# Altium schematic sheet T_Display_S3.SchDoc
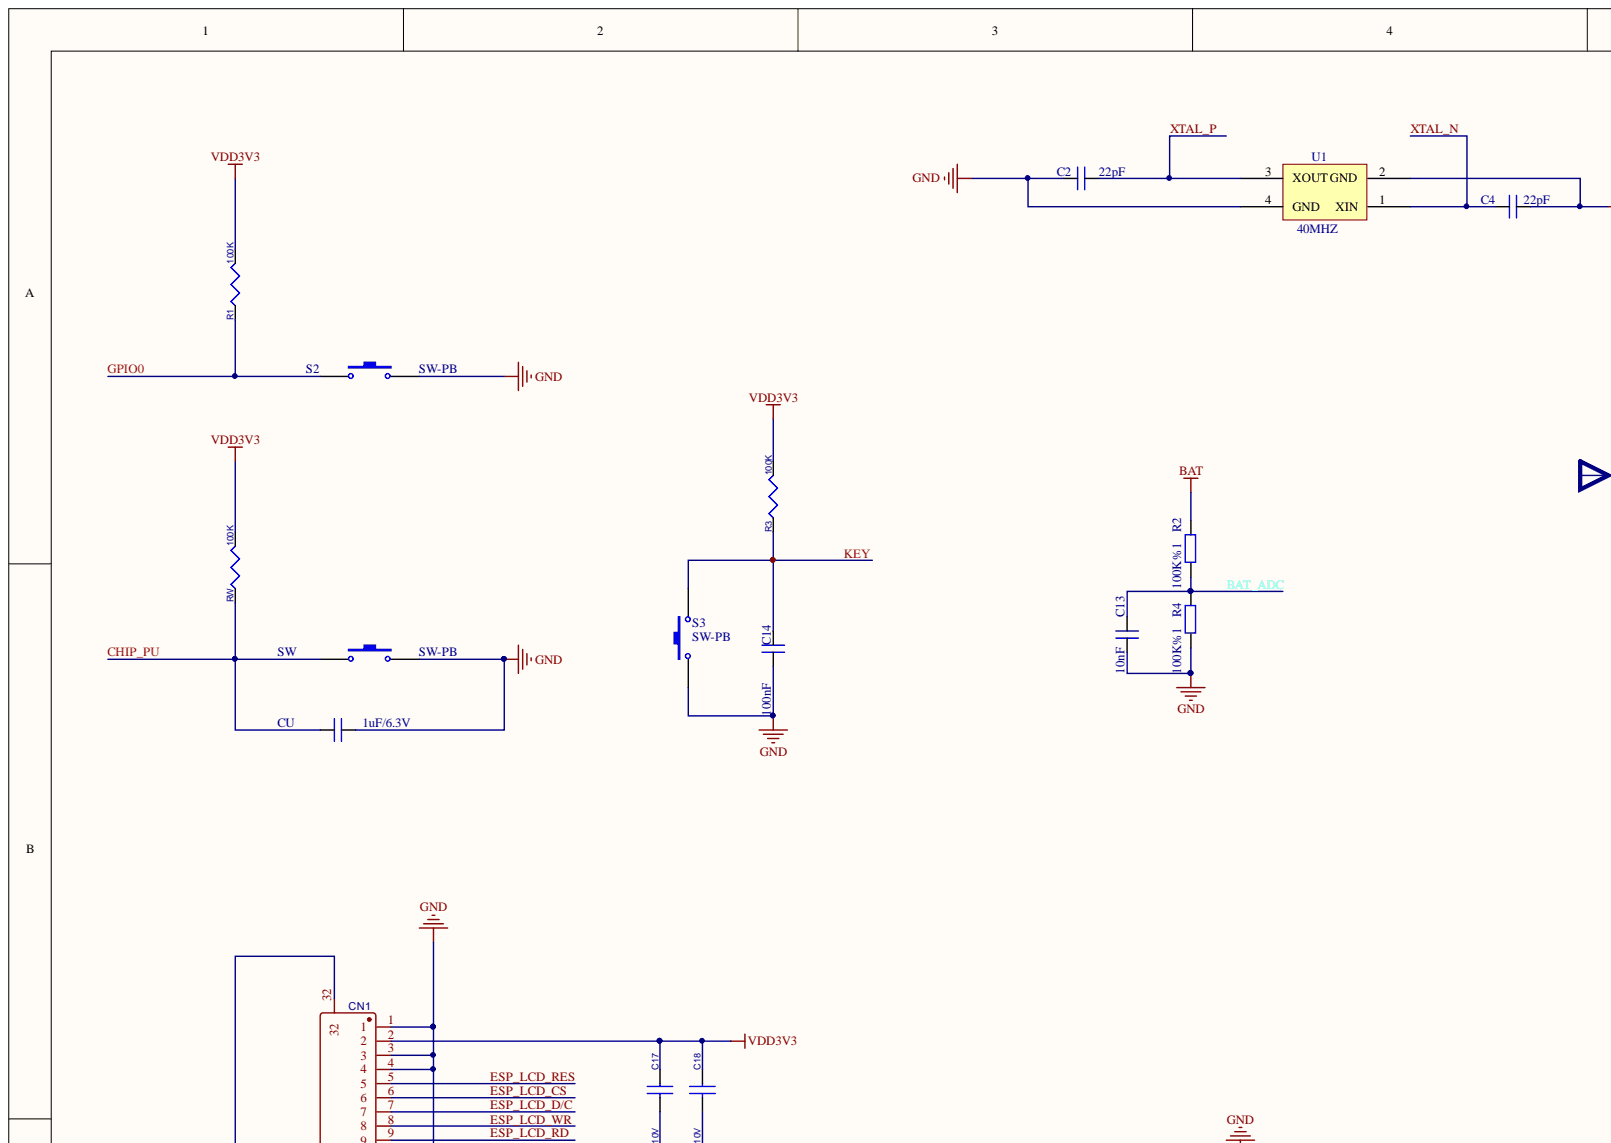
[diagram p0: T_Display_S3.SchDoc, top left]
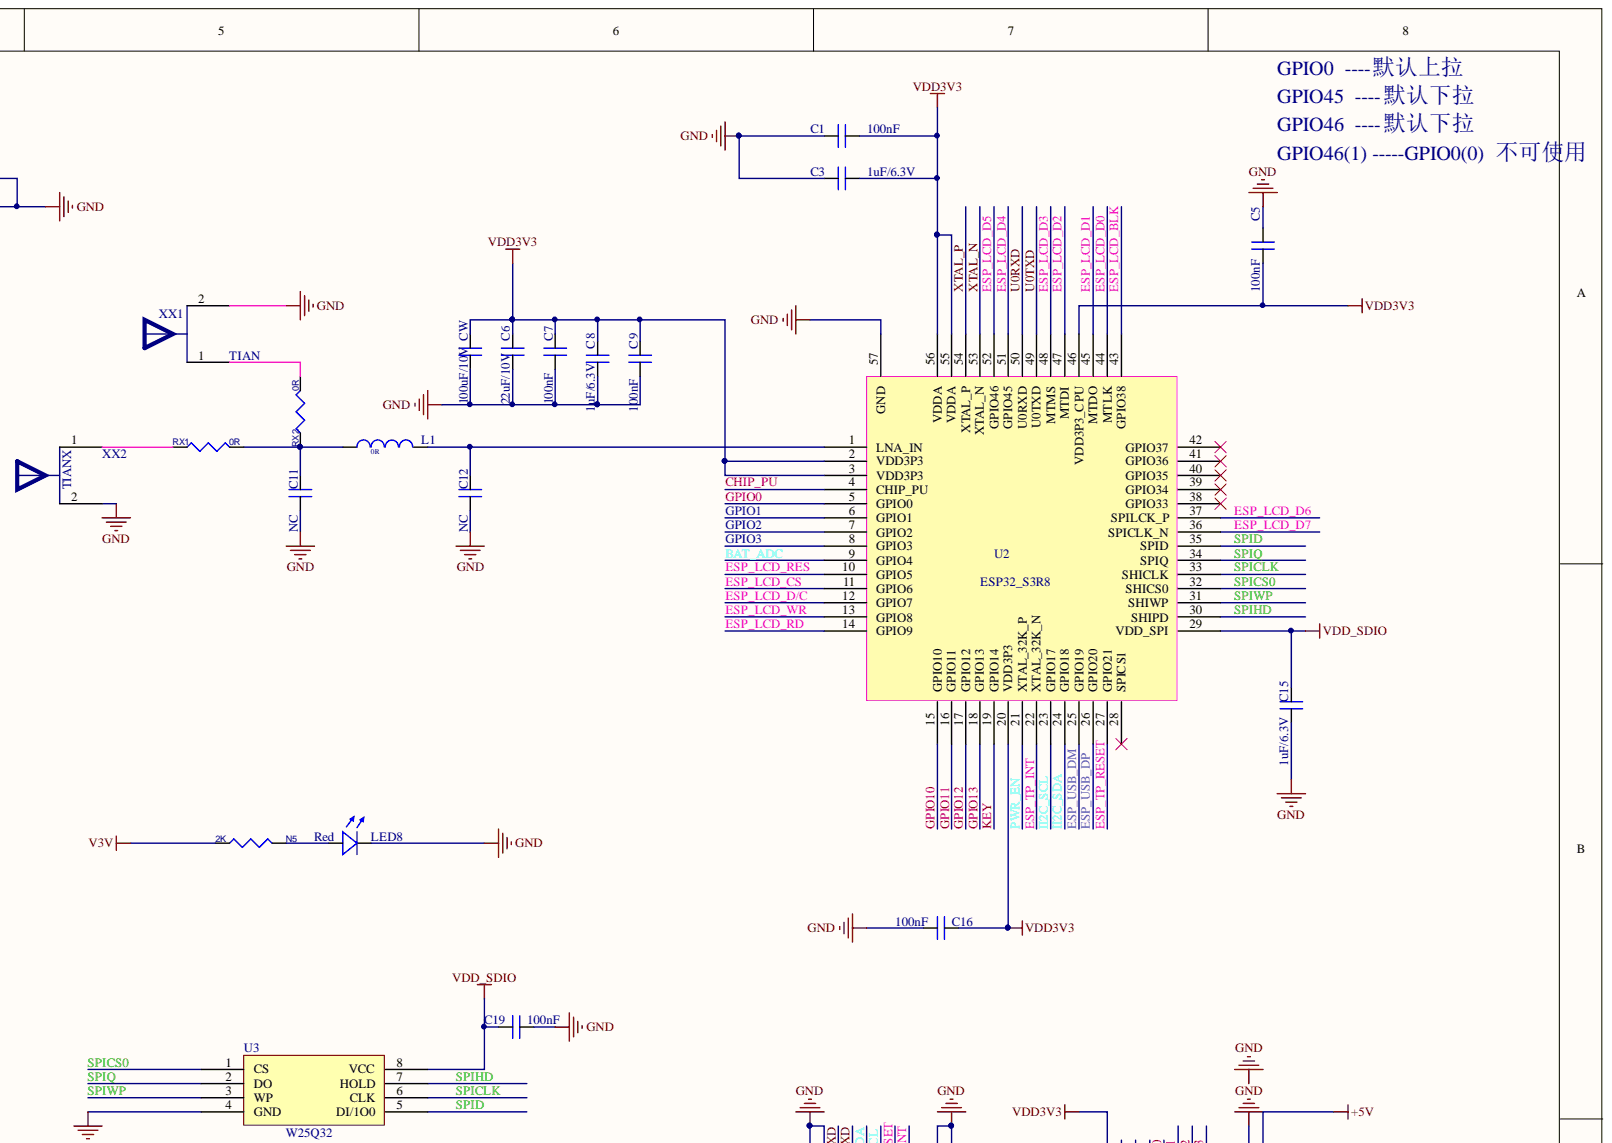
[diagram p1: T_Display_S3.SchDoc, top right]
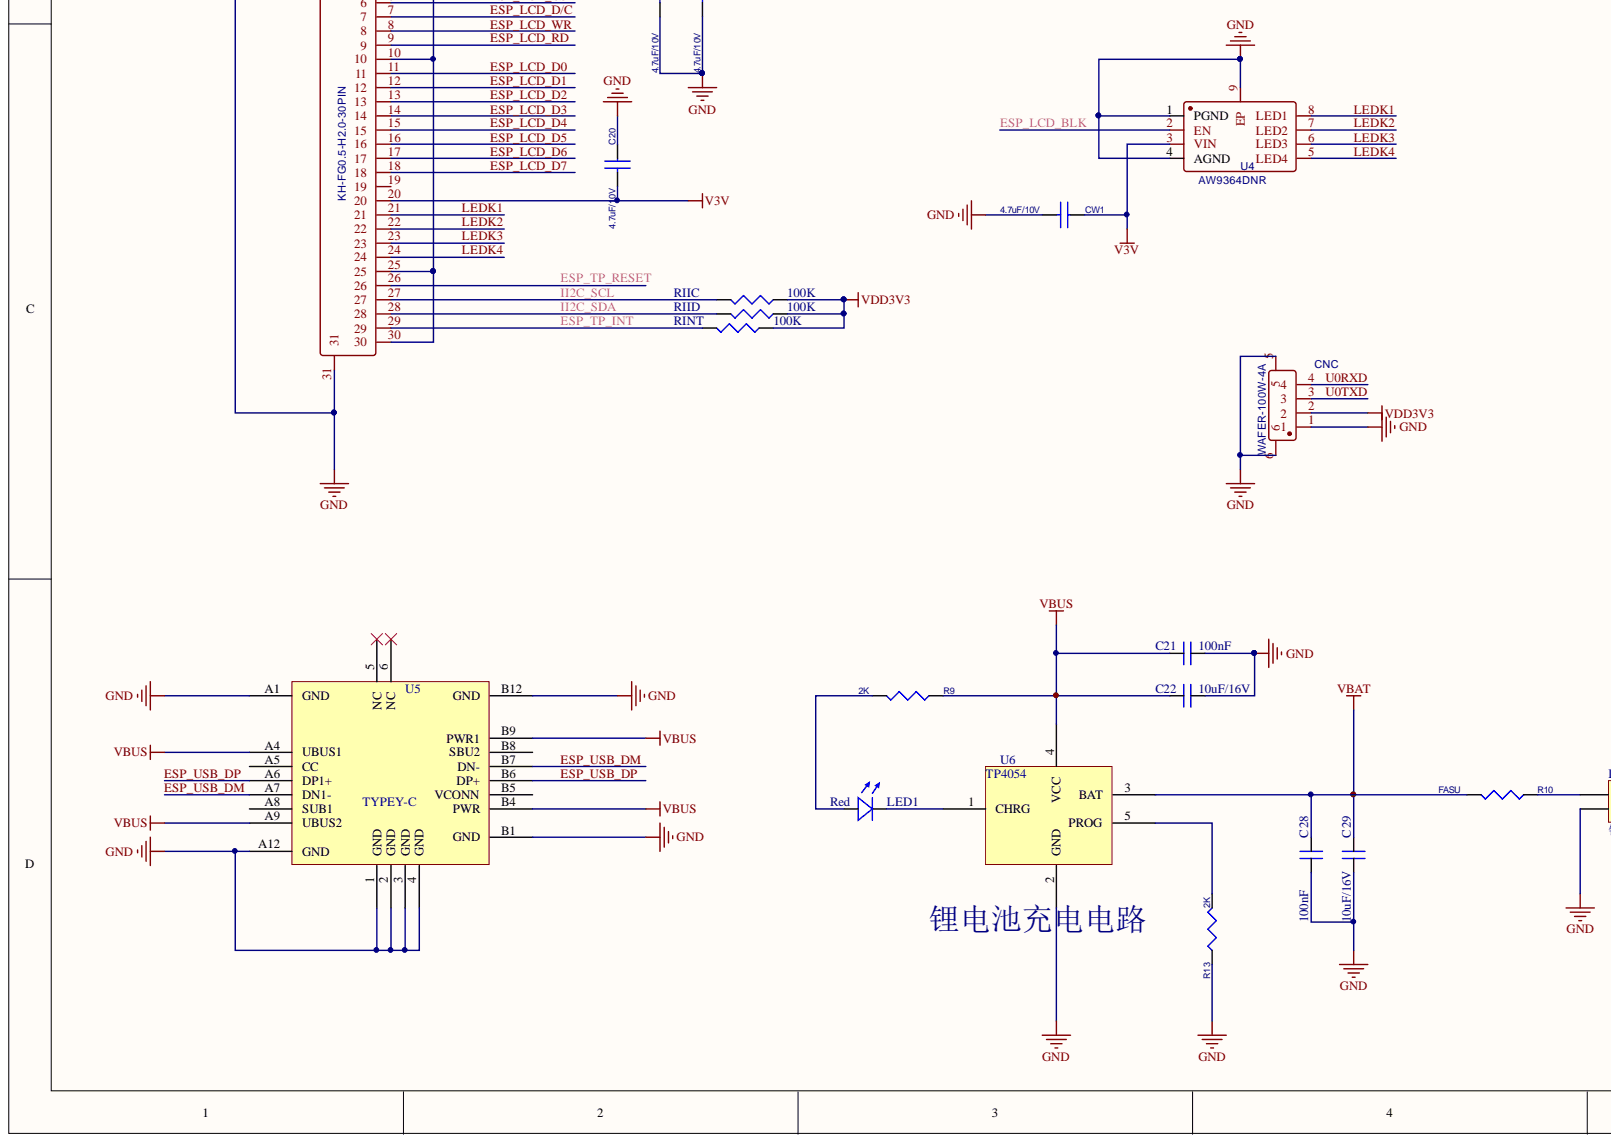
[diagram p2: T_Display_S3.SchDoc, bottom left]
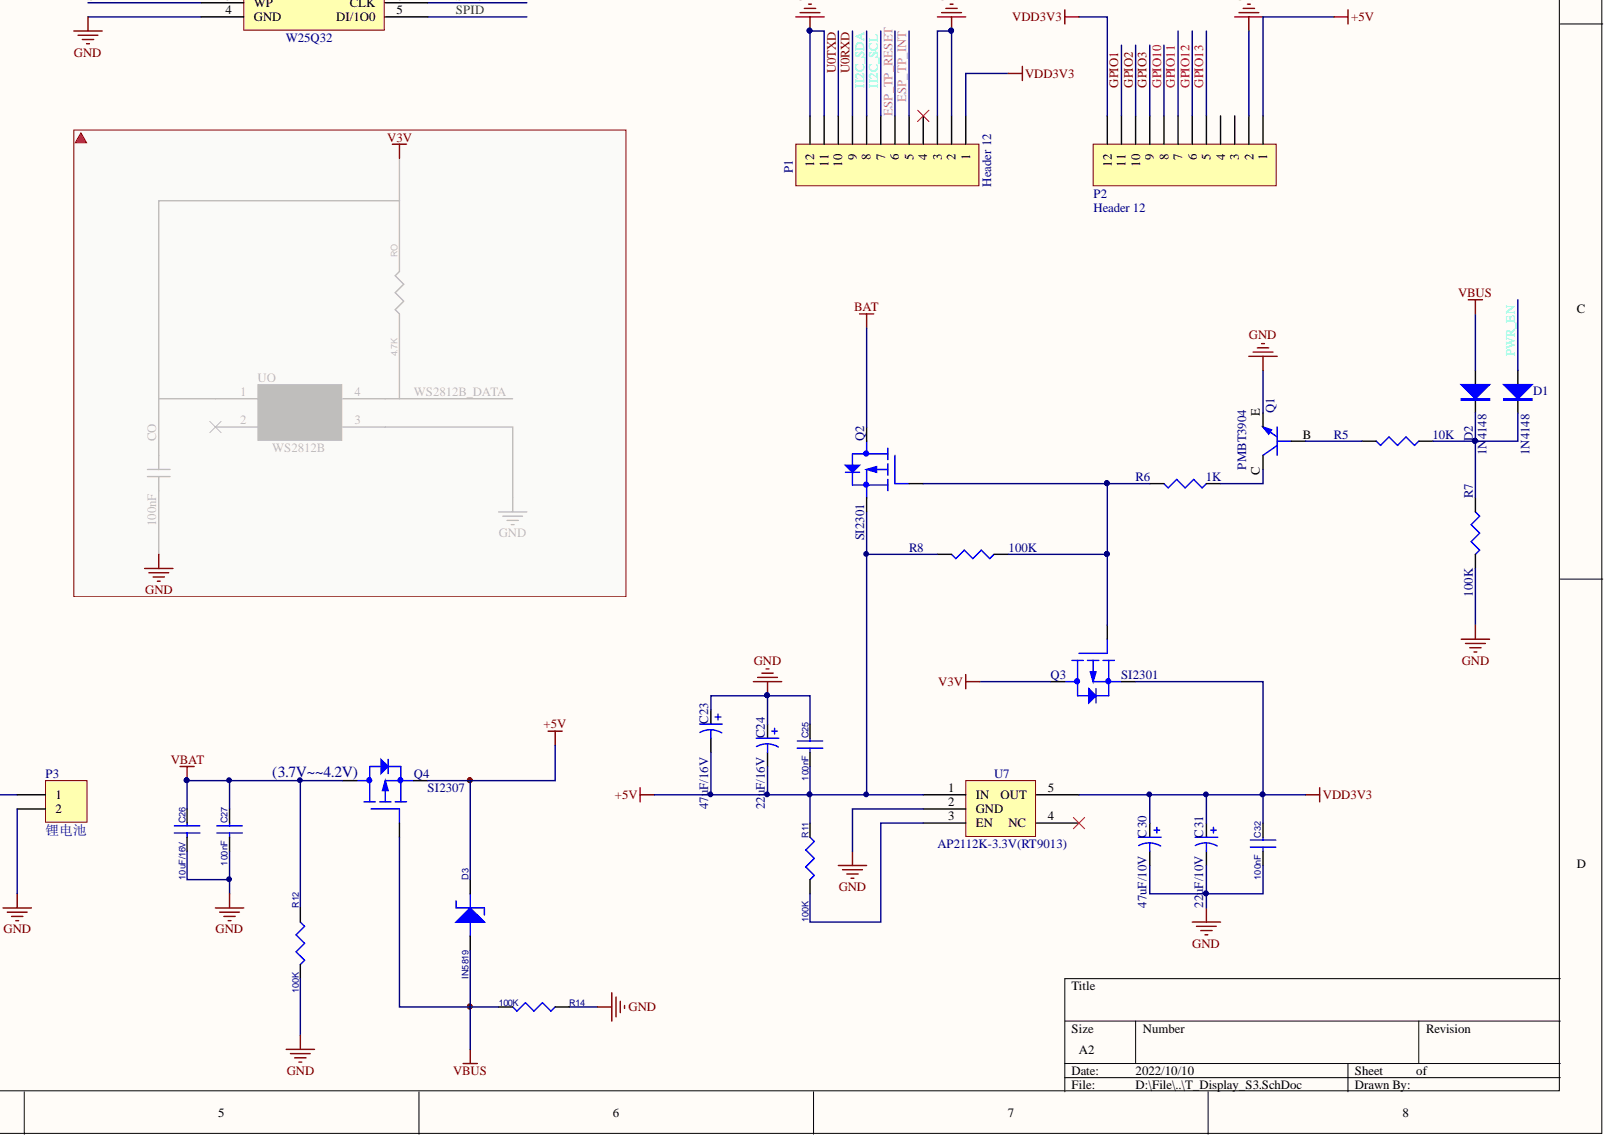
[diagram p3: T_Display_S3.SchDoc, bottom right]

## Parts
C1  100nF  [LC-0402_C]
C2  22pF  [LC-0402_C]
C3  1uF/6.3V  [LC-0402_C]
C4  22pF  [LC-0402_C]
C5  100nF  [LC-0402_C]
C6  22uF/10V  [LC-0805_C]
C7  100nF  [LC-0603_C]
C8  1uF/6.3V  [LC-0402_C]
C9  100nF  [LC-0402_C]
C11  NC  [LC-0402_C]
C12  NC  [LC-0402_C]
C13  10nF  [LC-0402_C]
C14  100nF  [LC-0402_C]
C15  1uF/6.3V  [LC-0402_C]
C16  100nF  [LC-0402_C]
C17  4.7uF/10V  [LC-0402_C]
C18  4.7uF/10V  [LC-0402_C]
C19  100nF  [LC-0402_C]
C20  4.7uF/10V  [LC-0402_C]
C21  100nF  [LC-0603_C]
C22  10uF/16V  [LC-0805_C]
C23  47uF/16V  [LC-1206_C]
C24  22uF/16V  [LC-0805_C]
C25  100nF  [LC-0603_C]
C26  10uF/16V  [LC-0603_C]
C27  100nF  [LC-0603_C]
C28  100nF  [LC-0603_C]
C29  10uF/16V  [LC-0603_C]
C30  47uF/10V  [LC-1206_C]
C31  22uF/10V  [LC-0805_C]
C32  100nF  [LC-0603_C]
CN1  KH-FG0.5-H2.0-30PIN  [FPC-SMD_KH-FG0.5-H2.0-30PIN]
CNC  WAFER-100W-4A  [CONN-SMD_4P-P1.00_XUNPU_WAFER-100W-4P]
CU  1uF/6.3V  [LC-0402_C]
CW  100uF/10V  [LC-0805_C]
CW1  4.7uF/10V  [LC-0402_C]
D1  1N4148  [LC-SOD-323]
D2  1N4148  [LC-SOD-323]
D3  IN5819  [SDO123]
L1  0R  [LC-0402_L]
LED1  Red  [LC-0402_R]
LED8  Red  [LC-0402_R]
N5  2K  [LC-0402_R]
P1  Header 12  [12P-2.54MM]
P2  Header 12  [12P-2.54MM]
P3  锂电池  [2P~1.27mm]
Q1  PMBT3904  [SOT-23 - BCE]
Q2  SI2301  [SOT-23 - GDS]
Q3  SI2301  [SOT-23 - GDS]
Q4  SI2307  [SOT-23 - GDS]
R1  100K  [LC-0402_R]
R2  100K%1  [LC-0402_R]
R3  100K  [LC-0402_R]
R4  100K%1  [LC-0402_R]
R5  10K  [LC-0402_R]
R6  1K  [LC-0402_R]
R7  100K  [LC-0402_R]
R8  100K  [LC-0402_R]
R9  2K  [LC-0402_R]
R10  FASU  [LC-0603_L]
R11  100K  [LC-0402_R]
R12  100K  [LC-0402_R]
R13  2K  [LC-0402_R]
R14  100K  [LC-0402_R]
RIIC  100K  [LC-0402_R]
RIID  100K  [LC-0402_R]
RINT  100K  [LC-0402_R]
RW  100K  [LC-0402_R]
RX1  0R  [LC-0402_R]
RX2  0R  [LC-0402_R]
S2  SW-PB  [KEY-4P]
S3  SW-PB  [KEY-4P]
SW  SW-PB  [TS-019B]
U1  40MHZ  [XTAL3225]
U2  ESP32_S3R8  [QFN-56]
U3  W25Q32  [SOP-8]
U4  AW9364DNR  [DFN-8_L2.0-W2.0-P0.50-BL-EP-1]
U5  TYPEY-C  [TYPE-C3.0]
U6  TP4054  [LC-SOT-23-5]
U7  AP2112K-3.3V(RT9013)  [LC-SOT-23-5]
XX1  TIAN  [ANT_JACK]
XX2  TIANX  [ANT_L_TYPEA_MIRROR]

## Nets
+5V: C23.2 C24.2 C25.2 D3.2 P2.1 Q2.S Q4.S R8.2 R11.1 U7.1(IN)
BAT: Q2.D R2.1
GND: C1.2 C2.1 C3.2 C4.2 C5.2 C6.2 C7.2 C8.2 C9.2 C11.2 C12.2 C13.2 C14.2 C15.1 C16.1 C17.2 C18.2 C19.2 C20.1 C21.1 C22.1 C23.1 C24.1 C25.1 C26.2 C27.2 C28.2 C29.2 C30.2 C31.2 C32.2 CN1.1 CN1.3 CN1.4 CN1.10 CN1.25 CN1.30 CN1.31 CN1.32 CNC.1 CNC.5 CNC.6 CU.1 CW.2 CW1.2 LED8.2 P1.2 P1.3 P1.11 P1.12 P2.2 P3.2 Q1.E R4.2 R7.2 R12.2 R13.1 R14.1 S2.2 S3.1 SW.2 U1.2(GND) U1.4(GND) U2.57(GND) U3.4(GND) U4.1(PGND) U4.4(AGND) U4.9(EP) U5.1(GND) U5.2(GND) U5.3(GND) U5.4(GND) U5.A1(GND) U5.A12(GND) U5.B1(GND) U5.B12(GND) U6.2(GND) U7.2(GND) XX1.2 XX2.2
V3V: C20.2 CN1.20 CW1.1 N5.1 Q3.D U4.3(VIN)
VBAT: C26.1 C27.1 C28.1 C29.1 Q4.D R10.2 R12.1 U6.3(BAT)
VBUS: C21.2 C22.2 D2.1 D3.1 Q4.G R9.1 R14.2 U5.A4(UBUS1) U5.A9(UBUS2) U5.B4(PWR) U5.B9(PWR1) U6.4(VCC)
VDD3V3: C1.1 C3.1 C5.1 C6.1 C7.1 C8.1 C9.1 C16.2 C17.1 C18.1 C30.1 C31.1 C32.1 CN1.2 CNC.2 CW.1 P1.1 P2.12 Q3.S R1.2 R3.2 RIIC.1 RIID.1 RINT.1 RW.2 U2.2(VDD3P3) U2.3(VDD3P3) U2.20(VDD3P3) U2.46(VDD3P3_CPU) U2.55(VDDA) U2.56(VDDA) U7.5(OUT)
VDD_SDIO: C15.2 C19.1 U2.29(VDD_SPI) U3.8(VCC)
BAT_ADC: C13.1 R2.2 R4.1 U2.9(GPIO4)
CHIP_PU: CU.2 RW.1 SW.3 U2.4(CHIP_PU)
ESP_LCD_BLK: U2.43(GPIO38) U4.2(EN)
ESP_LCD_CS: CN1.6 U2.11(GPIO6)
ESP_LCD_D0: CN1.11 U2.44(MTLK)
ESP_LCD_D1: CN1.12 U2.45(MTDO)
ESP_LCD_D2: CN1.13 U2.47(MTDI)
ESP_LCD_D3: CN1.14 U2.48(MTMS)
ESP_LCD_D4: CN1.15 U2.51(GPIO45)
ESP_LCD_D5: CN1.16 U2.52(GPIO46)
ESP_LCD_D6: CN1.17 U2.37(SPILCK_P)
ESP_LCD_D7: CN1.18 U2.36(SPICLK_N)
ESP_LCD_D/C: CN1.7 U2.12(GPIO7)
ESP_LCD_RD: CN1.9 U2.14(GPIO9)
ESP_LCD_RES: CN1.5 U2.10(GPIO5)
ESP_LCD_WR: CN1.8 U2.13(GPIO8)
ESP_TP_INT: CN1.29 P1.5 RINT.2 U2.22(XTAL_32K_N)
ESP_TP_RESET: CN1.26 P1.6 U2.27(GPIO21)
ESP_USB_DM: U2.25(GPIO19) U5.A7(DN1-) U5.B7(DN-)
ESP_USB_DP: U2.26(GPIO20) U5.A6(DP1+) U5.B6(DP+)
GPIO0: R1.1 S2.1 U2.5(GPIO0)
GPIO1: P2.11 U2.6(GPIO1)
GPIO2: P2.10 U2.7(GPIO2)
GPIO3: P2.9 U2.8(GPIO3)
GPIO10: P2.8 U2.15(GPIO10)
GPIO11: P2.7 U2.16(GPIO11)
GPIO12: P2.6 U2.17(GPIO12)
GPIO13: P2.5 U2.18(GPIO13)
II2C_SCL: CN1.27 P1.7 RIIC.2 U2.23(GPIO17)
II2C_SDA: CN1.28 P1.8 RIID.2 U2.24(GPIO18)
KEY: C14.1 R3.1 S3.2 U2.19(GPIO14)
LEDK1: CN1.21 U4.8(LED1)
LEDK2: CN1.22 U4.7(LED2)
LEDK3: CN1.23 U4.6(LED3)
LEDK4: CN1.24 U4.5(LED4)
PWR_EN: D1.1 U2.21(XTAL_32K_P)
SPICLK: U2.33(SHICLK) U3.6(CLK)
SPICS0: U2.32(SHICS0) U3.1(CS)
SPID: U2.35(SPID) U3.5(DI/1O0)
SPIHD: U2.30(SHIPD) U3.7(HOLD)
SPIQ: U2.34(SPIQ) U3.2(DO)
SPIWP: U2.31(SHIWP) U3.3(WP)
U0RXD: CNC.4 P1.9 U2.50(U0RXD)
U0TXD: CNC.3 P1.10 U2.49(U0TXD)
XTAL_N: C4.1 U1.1(XIN) U2.53(XTAL_N)
XTAL_P: C2.2 U1.3(XOUT) U2.54(XTAL_P)
NetC11_1: C11.1 L1.1 RX1.2 RX2.1
NetC12_1: C12.1 L1.2 U2.1(LNA_IN)
NetD1_2: D1.2 D2.2 R5.1 R7.1
NetLED1_1: LED1.1 R9.2
NetLED1_2: LED1.2 U6.1(CHRG)
NetLED8_1: LED8.1 N5.2
NetP3_1: P3.1 R10.1
NetQ1_B: Q1.B R5.2
NetQ1_C: Q1.C R6.1
NetQ2_G: Q2.G Q3.G R6.2 R8.1
NetR11_2: R11.2 U7.3(EN)
NetR13_2: R13.2 U6.5(PROG)
NetRX1_1: RX1.1 XX2.1
NetRX2_2: RX2.2 XX1.1

Not connected: CN1 19; P1 4; P2 3 4; U2 28(SPICS1) 38(GPIO33) 39(GPIO34) 40(GPIO35) 41(GPIO36) 42(GPIO37); U5 5(NC) 6(NC) A5(CC) A8(SUB1) B5(VCONN) B8(SBU2); U7 4(NC)

## Library data of the parts
C1-C9 C11-C16 C19 C21 C22 C28 C29 CU CW = C: Component Kind: Standard; Library Name: 光学蓝牙.SCHLIB
C17 C18 C20 C25-C27 C32 CW1 = CAP NP: Component Kind: Standard
C23 C24 C30 C31 = Cap Pol3: Polarized Capacitor (Surface Mount); Value: 100pF; Component Kind: Standard; LatestRevisionDate: 17-Jul-2002; LatestRevisionNote: Re-released for DXP Platform.; Library Name: Miscellaneous Devices.IntLib; PackageReference: C0805; Published: 8-Jun-2000; Publisher: Altium Limited
CN1 = KH-FG0.5-H2.0-30PIN: Contributor: LCSC; BOM_JLCPCB Part Class: Extended Part; BOM_Manufacturer Part: KH-FG0.5-H2.0-30PIN; BOM_Supplier: LCSC; BOM_Supplier Part: C2797216; Component Kind: Standard; JLCPCB Part Class: Extended Part; spicePre: C; spiceSymbolName: KH-FG0.5-H2.0-30PIN
CNC = WAFER-100W-4A: Contributor: LCSC; BOM_JLCPCB Part Class: Extended Part; BOM_Manufacturer Part: WAFER-100W-4A; BOM_Supplier: LCSC; BOM_Supplier Part: C2874471; Component Kind: Standard; spicePre: C; spiceSymbolName: WAFER-100W-4A
D1 D2 = M7: Component Kind: Standard; Library Name: WegeV1.IntLib
D3 = DIODE ZENER_4_1: room: power1; Component Kind: Standard; Library Name: 光学蓝牙.SCHLIB
L1 = (no symbol name): Power Inductor, 4.7uH, 0.7A, 260mR DCR; Manufacturer: Taiyo Yuden; Manufacturer Part Number: CBC2518T4R7M; Part Creator: JG; XMOS Part Number: E-09-0011; Approved: NO; Approx Volume Cost ($): 0.07; DEVICE: L; FORWARD_PCB: 1; LEVEL: STD; Max DC Resistance: 0.26R; Rated Current (Saturation): 0.68A; Rated Current (Temp Rise): 0.92A; Tolerance (%): 20; VALUE: 2.0nH; Component Kind: Standard; Datasheet: http://www.yuden.co.jp/us/product/pdf/ecb2_e.pdf; Digikey Link: http://search.digikey.com/scripts/DkSearch/dksus.dll?lang=en&site=US&keywords=CBC2518T4R7M; Library Name: MySchlib.SchLib; Max Height (mm): 2
LED1 LED8 = LED: Component Kind: Standard; Library Name: MyLibrary.IntLib
N5 R1 R3 R5-R14 RIIC RIID RINT RW RX1 RX2 = R: Component Kind: Standard
  N5 R1 R3 R9-R14 RW RX1 RX2: Library Name: 光学蓝牙.SCHLIB
P1 P2 = Header 12: Header, 12-Pin; Component Kind: Standard; LatestRevisionDate: 17-Jul-2002; LatestRevisionNote: Re-released for DXP Platform.; Library Name: Miscellaneous Connectors.IntLib; Publisher: Altium Limited
P3 = MHDR1X2: Header, 2-Pin; Component Kind: Standard; LatestRevisionDate: 17-Jul-2002; LatestRevisionNote: Re-released for DXP Platform.; Library Name: Miscellaneous Connectors.IntLib; Publisher: Altium Limited
Q2-Q4 = PWRMOSFET_EP_DGS_0: Component Kind: Standard
R2 R4 = Res2: Resistor; Value: 470K; Component Kind: Standard; LatestRevisionDate: 2013年12月24日; LatestRevisionNote: Re-released for DXP Platform.; Library Name: MyLibrary.IntLib; Published: 2013年12月24日
S2 S3 SW = SW-PB: Switch; Component Kind: Standard; LatestRevisionDate: 17-Jul-2002; LatestRevisionNote: Re-released for DXP Platform.; Library Name: Miscellaneous Devices.IntLib; PackageReference: SPST-2; Published: 24-Mar-1999; Publisher: Altium Limited
U1 = CRY-D3225: Component Kind: Standard; Library Name: 885阿里云PCB_Project1.SCHLIB
U2 = ESP32_S3: Component Kind: Standard; Library Name: 885阿里云PCB_Project1.SCHLIB
U3 = GD25LQ128DSIGR: Component Kind: Standard; Library Name: 885阿里云PCB_Project1.SCHLIB
U4 = AW9364DNR: Contributor: LCSC; BOM_JLCPCB Part Class: Extended Part; BOM_Manufacturer Part: AW9364DNR; BOM_Supplier: LCSC; BOM_Supplier Part: C401007; Component Kind: Standard; Frequency: -; spicePre: U; spiceSymbolName: AW9364DNR
U7 = AP2112K-3.3V: Component Kind: Standard; Library Name: 885阿里云PCB_Project1.SCHLIB
XX1 = TIANX: Component Kind: Standard; Library Name: 885阿里云PCB_Project1.SCHLIB
Q1 = PMBT3904: Component Kind: Standard
U5 = TYPEY-C: Component Kind: Standard; Library Name: 885阿里云PCB_Project1.SCHLIB
U6 = TP4054: Component Kind: Standard; Library Name: 885阿里云PCB_Project1.SCHLIB
XX2 = TIANX: Component Kind: Standard; Library Name: 885阿里云PCB_Project1.SCHLIB
